# Altium schematic sheet Stage0A_input_protection.SchDoc
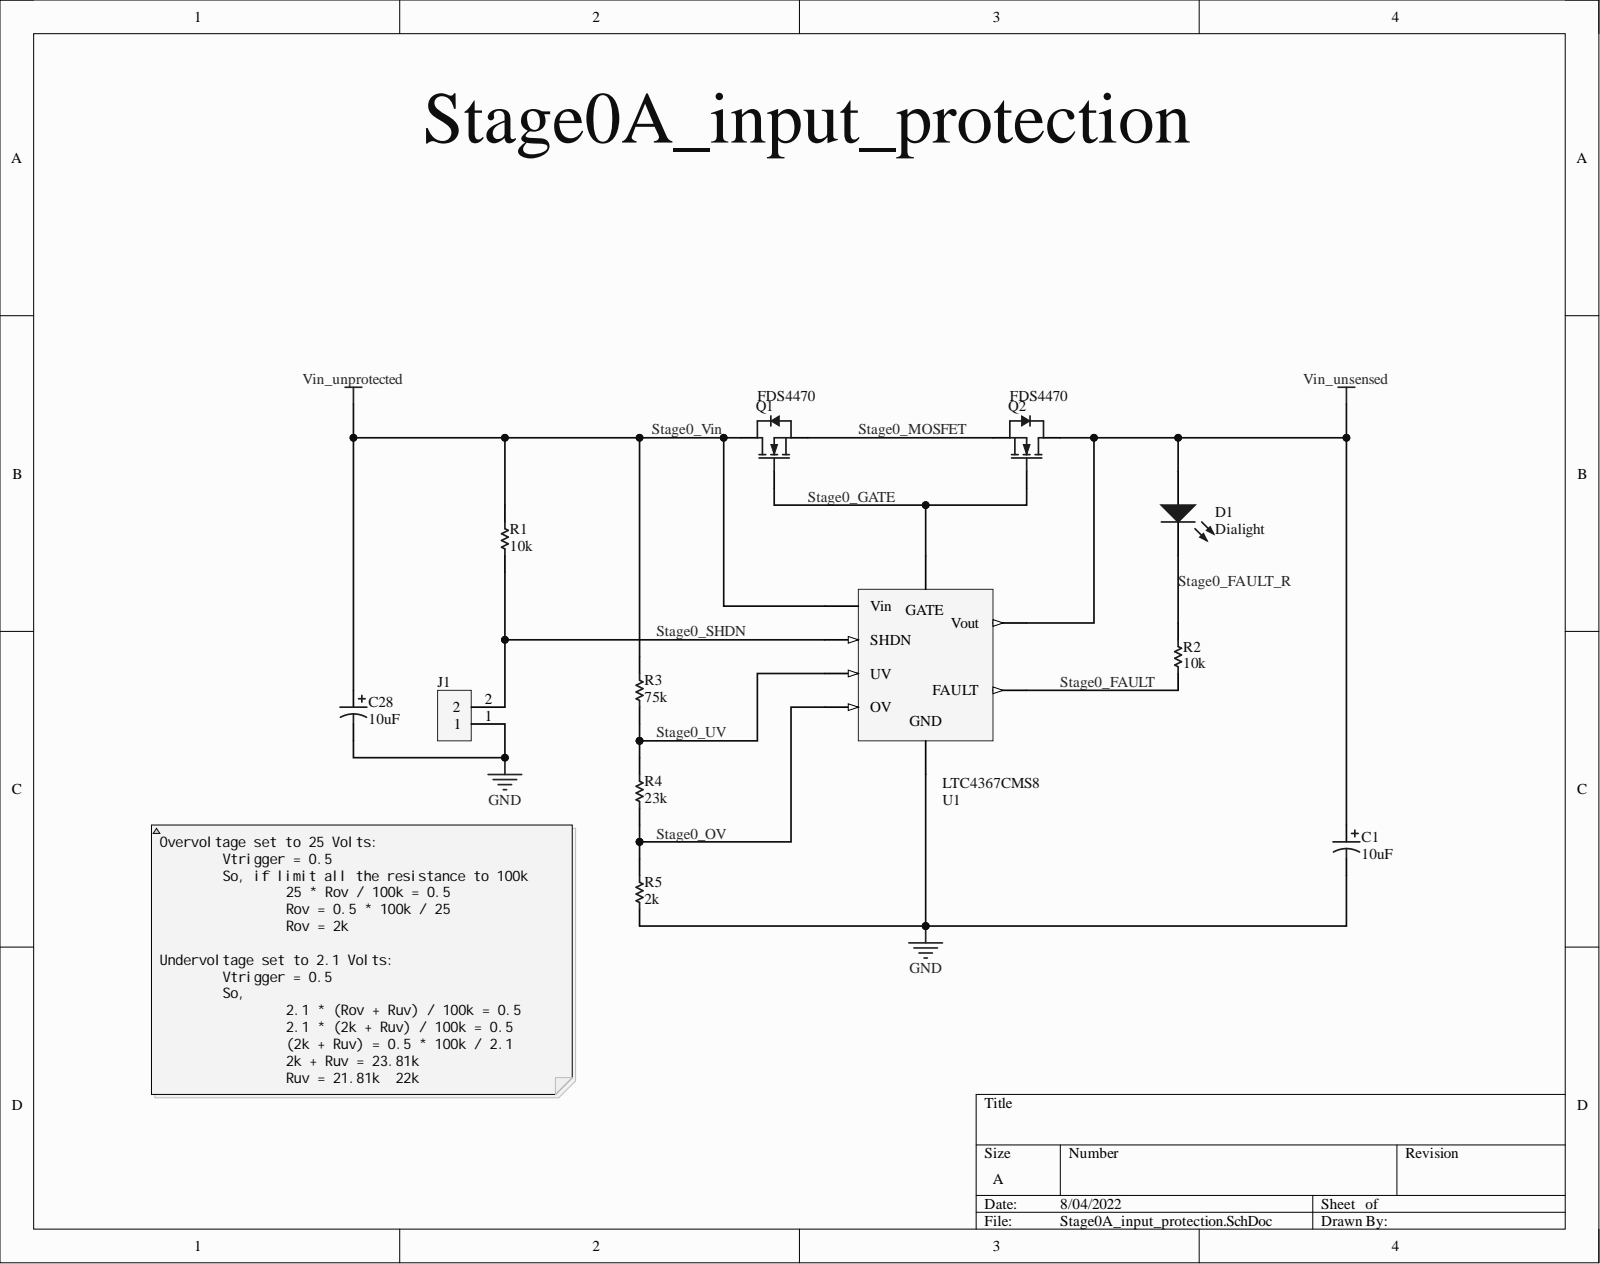
[diagram p0: Stage0A_input_protection.SchDoc]

## Parts
C1  10uF  [PCB-45uljczbzgwzo9m7ql45-1]
C28  10uF  [PCB-45uljczbzgwzo9m7ql45-1]
D1  Dialight  [PCB-9ifma0oh53wy532w7hco-2]
J1  (no value)  [PCB-omgsbkhp90n7lpri88og-1]
Q1  FDS4470  [PCB-vam1no1ldi8601k0q85a-1]
Q2  FDS4470  [PCB-vam1no1ldi8601k0q85a-1]
R1  10k  [PCB-s0mv190h6t9hazm0rgl3-1]
R2  10k  [PCB-s0mv190h6t9hazm0rgl3-1]
R3  75k  [RESC2013X70X40LL20T20]
R4  23k  [RESC2013X50X30LL20T20]
R5  2k  [RESC2013X55X40NL15T20]
U1  LTC4367CMS8  [PCB-4hte28pg97jmgossgcjj-2]

## Nets
GND: C1.2 C28.2 J1.1 R5.1 U1.4
Vin_unsensed: C1.1 D1.2 Q2.3 U1.7(Vout)
Stage0_FAULT: R2.1 U1.6(FAULT)
Stage0_FAULT_R: D1.1 R2.2
Stage0_GATE: Q1.1 Q2.1 U1.8
Stage0_MOSFET: Q1.2 Q2.2
Stage0_OV: R4.1 R5.2 U1.3(OV)
Stage0_SHDN: J1.2 R1.1 U1.5(SHDN)
Stage0_UV: R3.1 R4.2 U1.2(UV)
Stage0_Vin: C28.1 Q1.3 R1.2 R3.2 U1.1(Vin)

## Library data of the parts
C1 C28 = CMP-7610bd40367da9d7-6: Capacitor; Aluminum Electrolytic; Fc Radial Elec Cap 10uF 50V; Manufacturer Part Number: EEU-FC1H100L; Capacitance: 10.0 µF; Capacitance Tolerance: ±20%; Lead-Free Status: Lead Free; Voltage Rating (DC): 50.0 V; Component Kind: Standard; Dielectric Material: Aluminum Electrolytic; Library Name: My Personal Space; Lifecycle Status: Not Listed by Manufacturer; Mounting Style: Through Hole; Number of Pins: 2; Octopart URL: https://octopart.com/eeu-fc1h100l-panasonic-39478539; OctopartID: 7610bd40367da9d7; Operating Temperature: 105 °C (max); Packaging: Bulk; REACH SVHC Compliance: No SVHC; RoHS: Compliant; Size-Diameter: 5.00 mm; Size-Height: 11.0 mm; Size-Length: 11.0 mm
D1 = CMP-1071566-10: 598-8110-107F, Dialight, SMD LED 0805 Package, Red Single color SOLD IN INCREMENTS OF 4000. (REEL); Case/Package (SI): 2012; Forward Voltage: 2.20 V; Lead-Free Status: Lead Free; Lens Type: Non-Diffused; Luminous Intensity: 40.0 mcd; Viewing Angle: 140 °; Wavelength: 635 nm, 642 nm; Case/Package: 0805; Color: Red; Component Kind: Standard; Lens Color: Red; Library Name: My Personal Space; Manufacturer Part Number: 598-8110-107F; Mounting Style: Surface Mount, Vertical; Number of Pins: 2; Octopart URL: https://octopart.com/598-8110-107f-dialight-868569; OctopartID: bcc375c4d97c6304; Operating Temperature: 85.0 °C (max); Packaging: Cut Tape (CT); REACH SVHC Compliance: No SVHC; RoHS: Compliant; Size-Height: 700 µm; Size-Length: 2.00 mm; Size-Width: 1.25 mm
J1 = CMP-748fbcb73d6bab48-30: Pitch 2.54 mm, Single Row, 2pins; Component Kind: Standard; Library Name: My Personal Space
Q1 Q2 = CMP-cab003bfccd71577-2: FDS4470 Series 40 V 9 mOhm Surface Mount N-Channel PowerTrench Mosfet - SOIC-8; Breakdown Voltage (Drain to Source): -100.0 V; Continuous Drain Current (Ids): 12.5 A; Drain to Source Resistance (on) (Rds): 0.009 Ω; Drain to Source Voltage (Vds): 40.0 V; Gate Charge: 4.5e-08 C; Input Capacitance: 2.659e-09 F; Lead-Free Status: Lead Free; Power Dissipation: 2.5 W; Rise Time: 1.2e-08 s; Case/Package: SOIC; Component Kind: Standard; Current Rating: 12.5 A; Library Name: My Personal Space; Lifecycle Status: Active; Manufacturer Part Number: FDS4470; Mounting Style: Surface Mount; Number of Pins: 8; Octopart URL: http://octopart.com/fds4470-fairchild+semiconductor-336641; OctopartID: cab003bfccd71577; Packaging: Tape; Polarity: N-Channel; REACH SVHC Compliance: No SVHC; RoHS: Compliant; Voltage Rating (DC): 40.0 V
R1 R2 = CMP-9c1054914d7443d7-1: Res Thick Film 0805 10K Ohm 1% 1/2W ±200ppm/°C Molded SMD Punched Paper T/R; Manufacturer Part Number: ERJP6WF1002V; Case/Package (SI): 2012; Lead-Free Status: Lead Free; Power Rating: 500 mW; Resistance: 10.0 kΩ; Temperature Coefficient: -200 ppm/°C to 200 ppm/°C; Voltage Rating (DC): 150 V; Case/Package: 2012, 0805; Component Kind: Standard; Library Name: My Personal Space; Lifecycle Status: Not Listed by Manufacturer; Mounting Style: Surface Mount; Octopart URL: https://octopart.com/erjp6wf1002v-panasonic-25949186; OctopartID: 9c1054914d7443d7; Packaging: Tape & Reel (TR), Cut Tape (CT); REACH SVHC Compliance: No SVHC; Resistance Tolerance: ±1%; RoHS: Compliant
R3 = CMP-3656797-2: Thick Film Resistors - SMD 0805 75Kohms 1% Tol; Manufacturer Part Number: ERJ-6ENF7502V; Case/Package (SI): 2012; Lead-Free Status: Lead Free; Power Rating: 0.125 W; Resistance: 75000.0 Ω; Voltage Rating (DC): 150.0 V; Case/Package: 0805; Component Kind: Standard; Library Name: My Personal Space; Lifecycle Status: Not Listed by Manufacturer; Mounting Style: Surface Mount; Octopart Url: https://octopart.com/erj-6enf7502v-panasonic-55560765; OctopartID: 4fba85ffa0e1907d; Packaging: Cut Tape (CT); REACH SVHC Compliance: No SVHC; Resistance Tolerance: ±1%; RoHS: Compliant; Size-Height: 0.0006 m; Size-Length: 0.002 m; Size-Width: 0.00125 m
R4 = CMP-1867414-2: RES SMD 23.7K OHM 1% 1/8W 0805; Manufacturer Part Number: CRCW080523K7FKEA; Case/Package (SI): 2012; Lead-Free Status: Lead Free; Power Rating: 0.125 W; Resistance: 23700.0 Ω; Temperature Coefficient: 100.0 ppm/°C; Voltage Rating (DC): 150.0 V; Case/Package: 0805; Component Kind: Standard; Library Name: My Personal Space; Mounting Style: Surface Mount; Number of Pins: 2; Octopart Url: https://octopart.com/crcw080523k7fkea-vishay-39796934; OctopartID: 3dfdf6a8585de23a; Packaging: Cut Tape (CT); REACH SVHC Compliance: No SVHC; Resistance Tolerance: ±1%; RoHS: Compliant; Size-Height: 0.00045 m; Size-Length: 0.002 m; Size-Width: 0.00125 m
R5 = CMP-1953871-2: RES SMD 2K OHM 0.1% 1/8W 0805; Manufacturer Part Number: TNPW08052K00BEEN; Case/Package (SI): 2012; Lead-Free Status: Lead Free; Power Rating: 0.125 W; Resistance: 2000.0 Ω; Temperature Coefficient: 25.0 ppm/°C; Voltage Rating (DC): 150.0 V; Case/Package: 0805; Component Kind: Standard; Library Name: My Personal Space; Octopart Url: https://octopart.com/tnpw08052k00been-vishay+dale-40834544; OctopartID: 16dfddba2649c918; Packaging: Cut Tape (CT); Resistance Tolerance: ±0.1%; RoHS: Compliant
U1 = CMP-c469082046ae1bae-3: Controller Applications: Automotive, Industrial Automation, Laptops, Portable Instrumentation; Manufacturer Part Number: LTC4367CMS8-1#PBF; Lead-Free Status: Contains Lead; Supply Voltage (DC): 2.50 V (min), 60.0 V (max); Case/Package: MSOP; Component Kind: Standard; Library Name: My Personal Space; Lifecycle Status: Active; Number of Pins: 8; Octopart URL: https://octopart.com/ltc4367cms8-1%23pbf-analog+devices-88319905; OctopartID: c469082046ae1bae; Operating Temperature: 0.00 °C (min); RoHS: Compliant
